# Altium schematic sheet QSPI_soic16.SchDoc
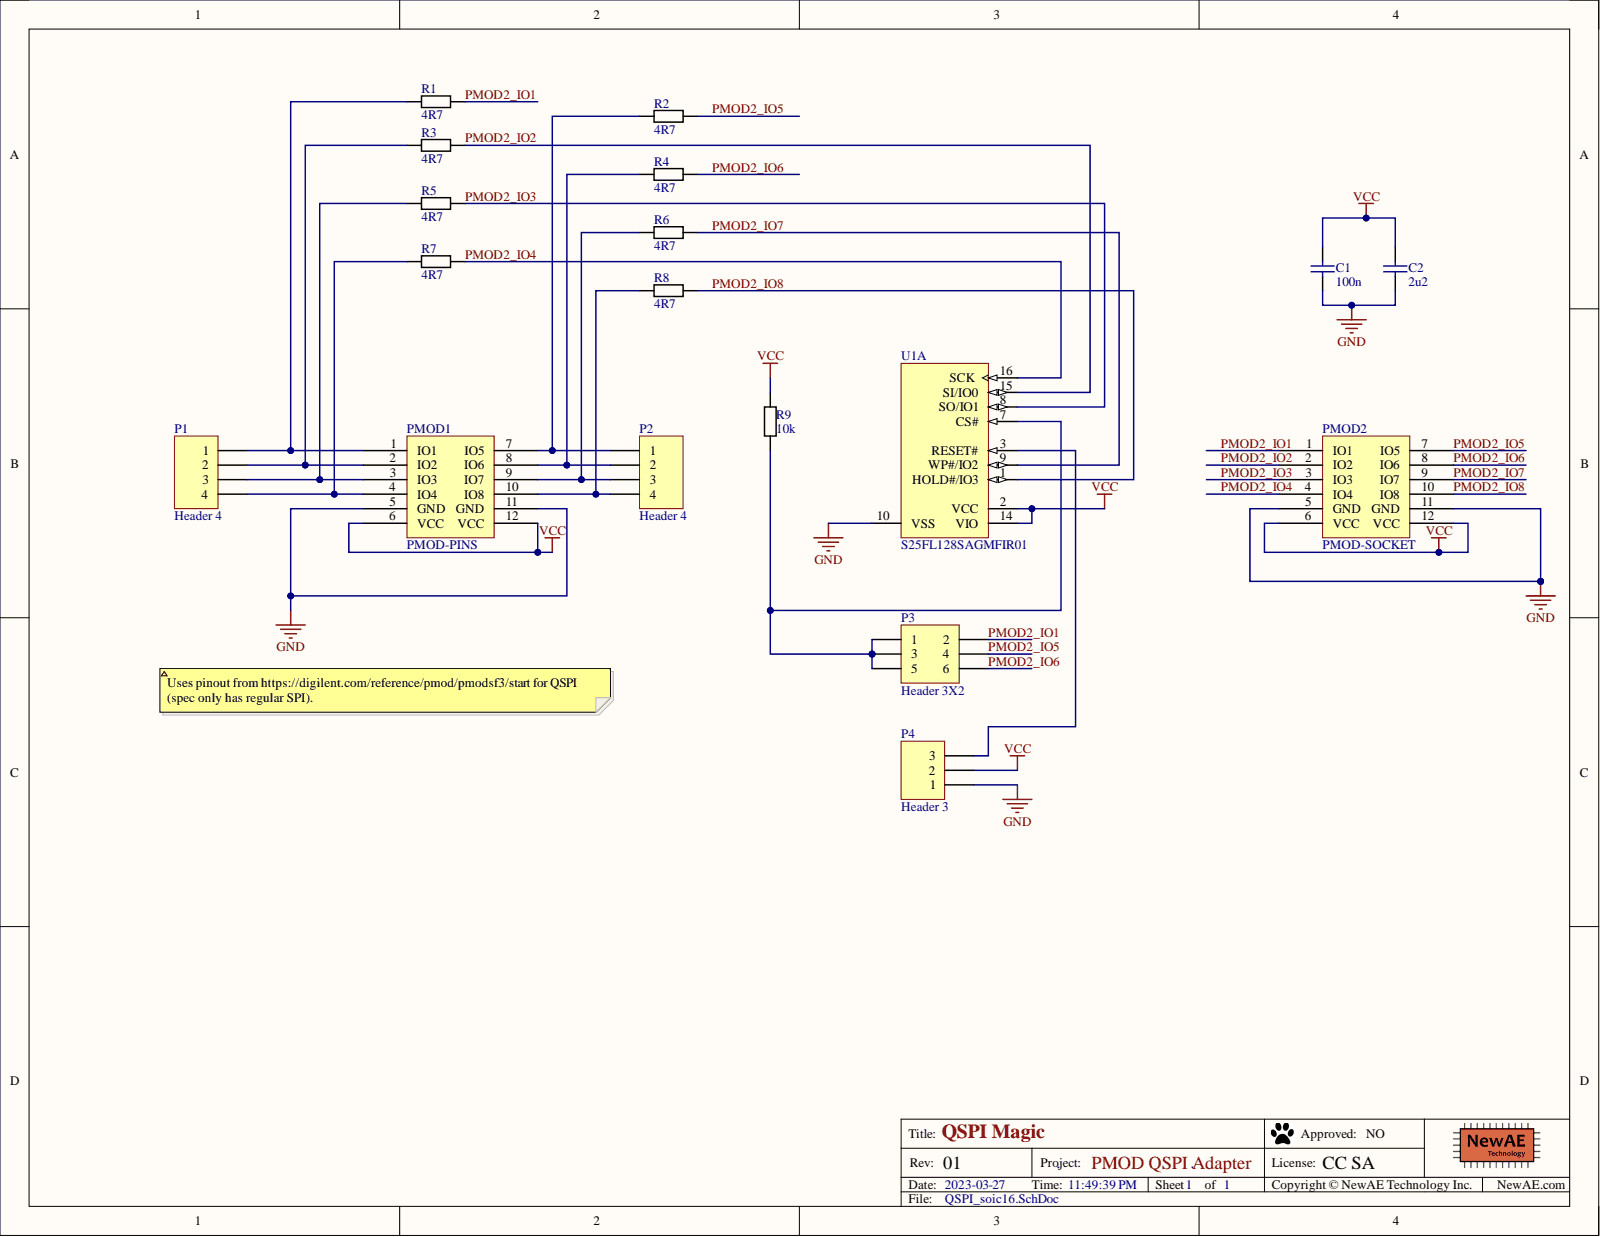
[diagram p0: QSPI_soic16.SchDoc]

## Parts
C1  100n  [0603]
C2  2u2  [0603]
P1  Header 4  [HDR1X4]
P2  Header 4  [HDR1X4]
P3  Header 3X2  [HDR2X3]
P4  Header 3  [HDR1X3]
PMOD1  PMOD-PINS  [PMOD-68021-212HLF-MALE]
PMOD2  PMOD-SOCKET  [SAMTEC_SSW_106_02_X_D_RA_PMOD]
R1  4R7  [0603]
R2  4R7  [0603]
R3  4R7  [0603]
R4  4R7  [0603]
R5  4R7  [0603]
R6  4R7  [0603]
R7  4R7  [0603]
R8  4R7  [0603]
R9  10k  [0603]
U1  S25FL128SAGMFIR01  [SPSN-SOIC-16_N]  (part A)

## Nets
GND: C1.2 C2.2 P4.1 PMOD1.5(GND) PMOD1.11(GND) PMOD2.5(GND) PMOD2.11(GND) U1.10(VSS)
VCC: C1.1 C2.1 P4.2 PMOD1.6(VCC) PMOD1.12(VCC) PMOD2.6(VCC) PMOD2.12(VCC) R9.2 U1.2(VCC) U1.14(VIO)
PMOD2_IO1: P3.2 PMOD2.1(IO1) R1.2
PMOD2_IO2: PMOD2.2(IO2) R3.2 U1.15(SI/IO0)
PMOD2_IO3: PMOD2.3(IO3) R5.2 U1.8(SO/IO1)
PMOD2_IO4: PMOD2.4(IO4) R7.2 U1.16(SCK)
PMOD2_IO5: P3.4 PMOD2.7(IO5) R2.2
PMOD2_IO6: P3.6 PMOD2.8(IO6) R4.2
PMOD2_IO7: PMOD2.9(IO7) R6.2 U1.9(WP#/IO2)
PMOD2_IO8: PMOD2.10(IO8) R8.2 U1.1(HOLD#/IO3)
NetP1_1: P1.1 PMOD1.1(IO1) R1.1
NetP1_2: P1.2 PMOD1.2(IO2) R3.1
NetP1_3: P1.3 PMOD1.3(IO3) R5.1
NetP1_4: P1.4 PMOD1.4(IO4) R7.1
NetP2_1: P2.1 PMOD1.7(IO5) R2.1
NetP2_2: P2.2 PMOD1.8(IO6) R4.1
NetP2_3: P2.3 PMOD1.9(IO7) R6.1
NetP2_4: P2.4 PMOD1.10(IO8) R8.1
NetP3_1: P3.1 P3.3 P3.5 R9.1 U1.7(CS#)
NetP4_3: P4.3 U1.3(RESET#)

## Library data of the parts
C1 = CCHIP-100n-0603: 100n, 0603; Manufacture 1: Kemet; Manufacture Part Number 1: C0603C104K5RACTU; Case-Metric: 1608; Component Group: Capacitor; Database Table Name: main; Rated Voltage: 50VDC; Case-EIA: 0603; Component Kind: Standard; Component Type: Standard; Library Name: NewAE_AltiumLib.DBLib; Mounting Technology: SMT; Part Number: CCHIP-100n-0603; Pin Count: 2; Supplier 1: Digi-Key; Supplier Part Number 1: 399-5089-2-ND; Value: 100n
C2 = CCHIP-2u2-0603: 2u2, 0603; Manufacture 1: Samsung; Manufacture Part Number 1: CL10B225KP8NNNC; Case-Metric: 1608; Component Group: Capacitor; Database Table Name: main; Rated Voltage: 10VDC; Case-EIA: 0603; Component Kind: Standard; Component Type: Standard; Library Name: NewAE_AltiumLib.DBLib; Mounting Technology: SMT; Part Number: CCHIP-2u2-0603; Pin Count: 2; Supplier 1: Digi-Key; Supplier Part Number 1: 1276-1134-1-ND; Value: 2u2
P1 P2 = Header 4: Header, 4-Pin; Component Kind: Standard; LatestRevisionDate: 17-Jul-2002; LatestRevisionNote: Re-released for DXP Platform.; Library Name: Miscellaneous Connectors.IntLib; Signal Integrity: Connector; Publisher: Altium Limited
P3 = CMP-011-000094-1: Header, 3-Pin, Dual row; Component Kind: Standard; Component Type: Standard; Design Item ID: Header 3X2; LatestRevisionDate: 17-Jul-2002; LatestRevisionNote: Re-released for DXP Platform.; Library Name: NewAE Technology; Pin Count: 6; Signal Integrity: Connector; Source: Miscellaneous Connectors.SchLib; Publisher: Altium Limited
P4 = CMP-004-000002-1: Header, 3-Pin; Component Kind: Standard; Component Type: Standard; Design Item ID: Header 3; LatestRevisionDate: 17-Jul-2002; LatestRevisionNote: Re-released for DXP Platform.; Library Name: NewAE Technology; Pin Count: 3; Signal Integrity: Connector; Publisher: Altium Limited; Source: CW313_20pinToTarget.SCHLIB
PMOD1 = PMOD_2ROW_MALERATH: Component Group: Connector; Database Table Name: main; PP Material Stack: 0; Component Kind: Standard; Component Type: Standard; Library Name: NewAE_AltiumLib.DBLib; Part Number: PMOD_2ROW_MALERATH; Pin Count: 12; PP Rotation: 0; PP Rotation1: 0; Supplier 1: Digi-Key
PMOD2 = PMOD_2ROW_FEMALERATH: Component Group: Connector; Database Table Name: main; PP Material Stack: 0; Component Kind: Standard; Component Type: Standard; Library Name: NewAE_AltiumLib.DBLib; Part Number: PMOD_2ROW_FEMALERATH; Pin Count: 12; PP Rotation: 0; PP Rotation1: 0; Supplier 1: Digi-Key; Supplier Part Number 1: SAM1215-06-ND
R1-R8 = RCHIP-4R7-0603: 4R7 res, 0603, 1%, 1/10W; Manufacture 1: Yageo; Manufacture Part Number 1: RC0603FR-074R7L; Case-Metric: 1608; Component Group: Resistor; Database Table Name: main; Rated Power: 0.1W; Rated Voltage: 75VDC; Case-EIA: 0603; Component Kind: Standard; Component Type: Standard; Library Name: NewAE_AltiumLib.DBLib; Mounting Technology: SMT; Part Number: RCHIP-4R7-0603; Pin Count: 2; Supplier 1: Digi-Key; Supplier Part Number 1: YAG3371CT-ND; Temperature Range: -55C to 155C; Tolerance: 1%; Value: 4R7
R9 = RCHIP-10K-0603: 10k res, 0603, 1%, 1/10W; Manufacture 1: Yageo; Manufacture Part Number 1: RC0603FR-0710KL; Case-Metric: 1608; Component Group: Resistor; Database Table Name: main; Rated Power: 0.1W; Rated Voltage: 75VDC; Case-EIA: 0603; Component Kind: Standard; Component Type: Standard; Library Name: NewAE_AltiumLib.DBLib; Mounting Technology: SMT; Part Number: RCHIP-10K-0603; Pin Count: 2; Supplier 1: Digi-Key; Supplier Part Number 1: 311-10.0KHRCT-ND; Temperature Range: -55C to 155C; Tolerance: 1%; Value: 10k
U1 = CMP-1329-00021-1: MirrorBit Flash Non-Volatile Memory CMOS 3.0 Volt Core with Versatile I/O, Serial Peripheral Interface with Multi-I/O, 128 Mbit, 133 MHz, -40 to 85 degC, 16-Pin SO (SO3016), RoHS, Tube; Manufacturer: Spansion; OTP: 1024 B; Speed: 133 MHz; VCC: 2.7 to 3.6 V; Component Kind: Standard; ComponentLink1Description: Manufacturer URL; ComponentLink1URL: http://www.spansion.com/Pages/Default.aspx; ComponentLink2Description: Datasheet; ComponentLink2URL: http://www.spansion.com/Support/Datasheets/S25FL128S_256S_00.pdf; ComponentLink3Description: Package Specification; ComponentLink3URL: http://www.spansion.com/Support/Datasheets/S25FL128S_256S_00.pdf; DatasheetVersion: Rev. 08; Density: 128 Mbit; Library Name: Altium Content Vault; Mounting Technology: Surface Mount; PackageDescription: 16-Pin Wide Plastic Small Outline Package, Body 10.3 x 7.5 mm, Pitch 1.27 mm; Packing: Tube; PartNumber: S25FL128SAGMFIR01; RoHS: TRUE; Temperature Range: -40 to 85 degC
